# Altium schematic sheet Main.SchDoc
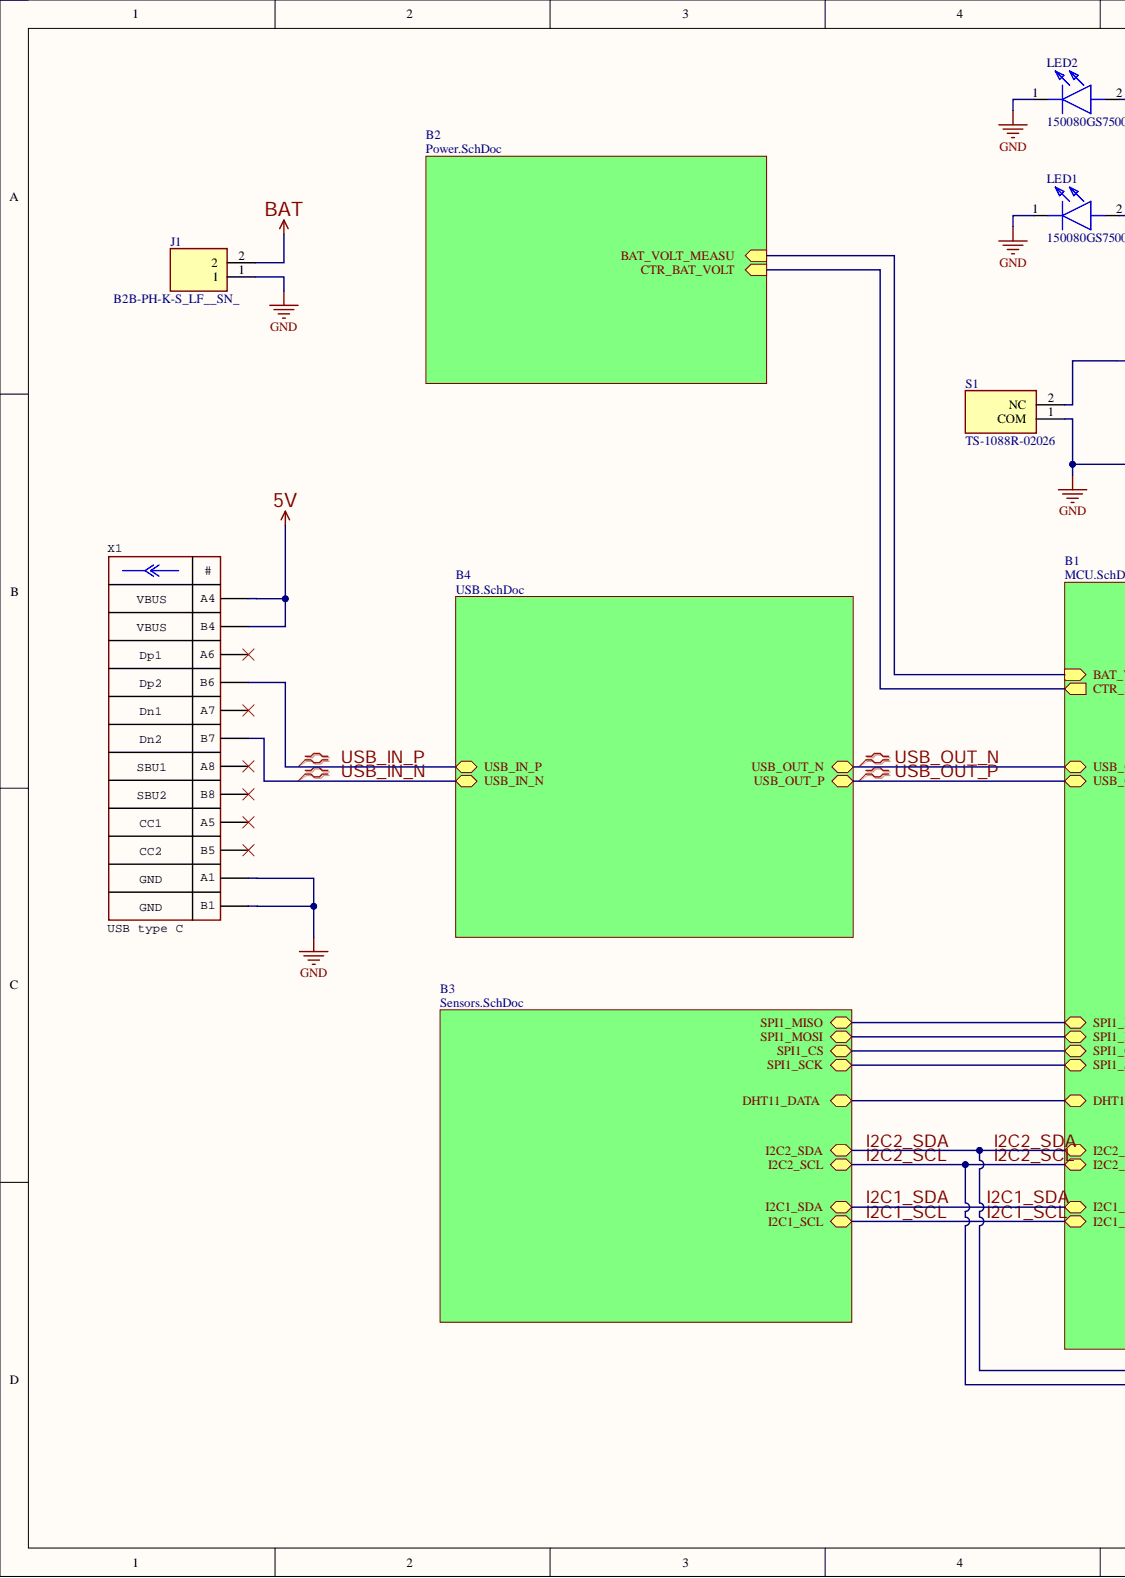
[diagram p0: Main.SchDoc, left]
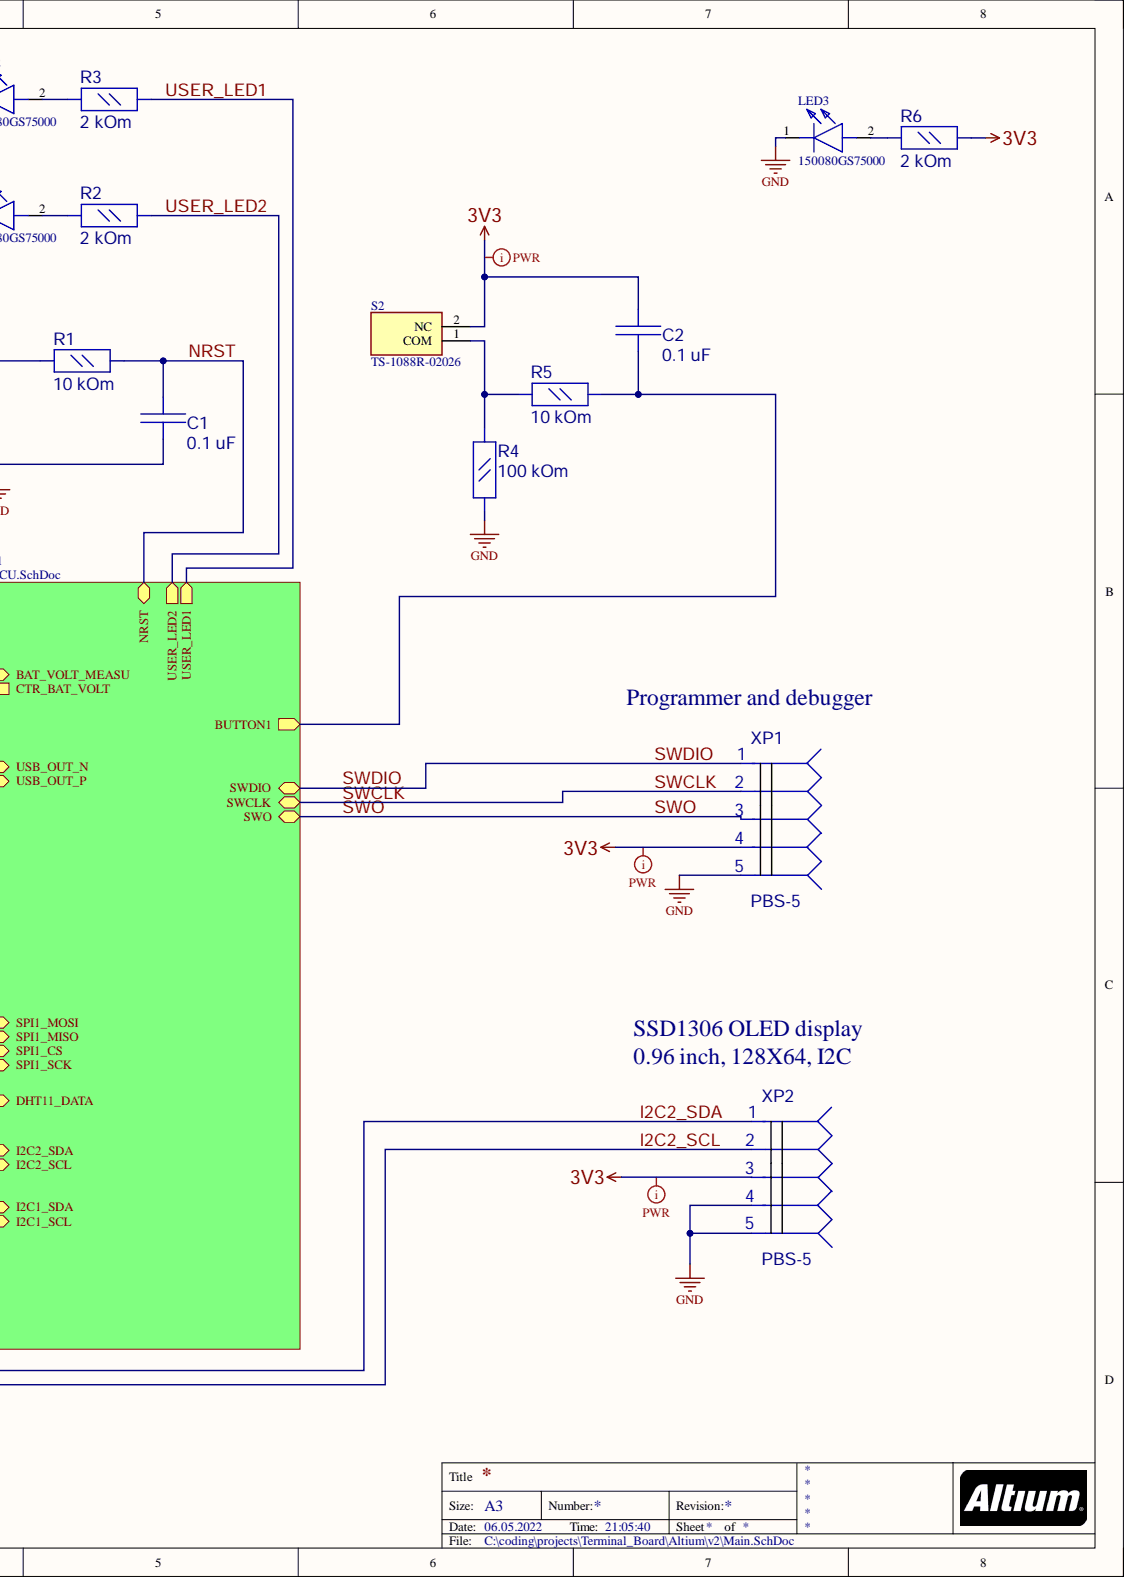
[diagram p1: Main.SchDoc, right]

## Parts
C1  0.1 uF  [C_0805]
C2  0.1 uF  [C_0805]
J1  B2B-PH-K-S_LF__SN_  [SHDR2W50P0X200_1X2_590X450X600P]
LED1  150080GS75000  [LEDM2012X80N]
LED2  150080GS75000  [LEDM2012X80N]
LED3  150080GS75000  [LEDM2012X80N]
R1  10 kOm  [R_0805]
R2  2 kOm  [R_0805]
R3  2 kOm  [R_0805]
R4  100 kOm  [R_0805]
R5  10 kOm  [R_0805]
R6  2 kOm  [R_0805]
S1  TS-1088R-02026  [TS1088R02026]
S2  TS-1088R-02026  [TS1088R02026]
X1  CommentText: USB type C  [CON: TYPE-C-31-M-12]  (hidden comment: USB Type C, 3A)
XP1  PBS-5  [PBS-5]
XP2  PBS-5  [PBS-5]

## Nets
3V3: C2.2 R6.1 S2.2 XP1.4 XP2.3
5V: X1.A4 X1.B4
BAT: J1.2
GND: C1.1 J1.1 LED1.1 LED2.1 LED3.1 R4.2 S1.1 X1.A1 X1.B1 XP1.5 XP2.4 XP2.5
I2C2_SCL: XP2.2
I2C2_SDA: XP2.1
NRST: C1.2 R1.1
SWCLK: XP1.2
SWDIO: XP1.1
SWO: XP1.3
USB_IN_N: X1.B7
USB_IN_P: X1.B6
USER_LED1: R3.1
USER_LED2: R2.1
NetC2_1: C2.1 R5.1
NetLED1_2: LED1.2 R2.2
NetLED2_2: LED2.2 R3.2
NetLED3_2: LED3.2 R6.2
NetR1_2: R1.2 S1.2
NetR4_1: R4.1 R5.2 S2.1
NetX1_A5: X1.A5
NetX1_A6: X1.A6
NetX1_A7: X1.A7
NetX1_A8: X1.A8
NetX1_B5: X1.B5
NetX1_B8: X1.B8
BAT_VOLT_MEASU:
CTR_BAT_VOLT:
DHT11_DATA:
I2C1_SCL:
I2C1_SDA:
SPI1_CS:
SPI1_MISO:
SPI1_SCK:
USB_OUT_N:
USB_OUT_P:

## Library data of the parts
C1 C2 = C_0805: Value: 100nF; Component Kind: Standard; Library Name: 2.Capasitors.SchLib
J1 = B2B-PH-K-S_LF__SN_: Connector; Manufacturer_Name: JST (JAPAN SOLDERLESS TERMINALS); Manufacturer_Part_Number: B2B-PH-K-S(LF)(SN); Arrow Part Number: B2B-PH-K-S(LF)(SN); Arrow Price/Stock: https://www.arrow.com/en/products/b2b-ph-k-s-lf-sn/jst-manufacturing; Component Kind: Standard; Datasheet Link: https://datasheet.lcsc.com/szlcsc/JST-Sales-America-B2B-PH-K-S-LF-SN_C131337.pdf; Height: 6mm
LED1-LED3 = 150080GS75000: LED; Manufacturer_Name: Wurth Elektronik; Component Kind: Standard; Datasheet Link: https://katalog.we-online.com/led/datasheet/150080GS75000.pdf; Height: 0.8mm; Manufacturer_Part_Number: 150080GS75000; Mouser Part Number: 710-150080GS75000; Mouser Price/Stock: https://www.mouser.co.uk/ProductDetail/Wurth-Elektronik/150080GS75000?qs=2kOmHSv6VfQRoTEZVk1mGA%3D%3D
R1 R5 R6 = R_0805: Component Kind: Standard; Library Name: 1.Resistors.SchLib
  R1 R5: Value: 10 kOm
  R6: Value: 2 kOm
R2 R3 = R_0805: Value: 510 Om; Component Kind: Standard; Library Name: 1.Resistors.SchLib
R4 = R_0805: Value: 10 kOm; Component Kind: Standard; Library Name: 1.Resistors.SchLib
S1 S2 = TS-1088R-02026: Switch; Manufacturer_Name: XUNPU; Component Kind: Standard; Datasheet Link: https://datasheet.lcsc.com/szlcsc/1912111437_XUNPU-TS-1088R-02026_C455280.pdf; Height: 2.2mm; Manufacturer_Part_Number: TS-1088R-02026
X1 = Connector_USB_Type_C: Manufacturer: Korean Hroparts; PartNumber: TYPE-C-31-M-12; Component Kind: Standard; CurrentMax: 3A; HelpURL: https://lcsc.com/product-detail/USB-Type-C_Korean-Hroparts-Elec-TYPE-C-31-M-12_C165948.html; Library Name: connector.SchLib; TypeConnector: USB Type C; ValueManufacturerPartNumber: USB Type C, 3A
XP1 XP2 = PBS-5: Component Kind: Standard; Library Name: 7.Connectors.SchLib
